# Altium schematic sheet Arduino_Mega.SchDoc
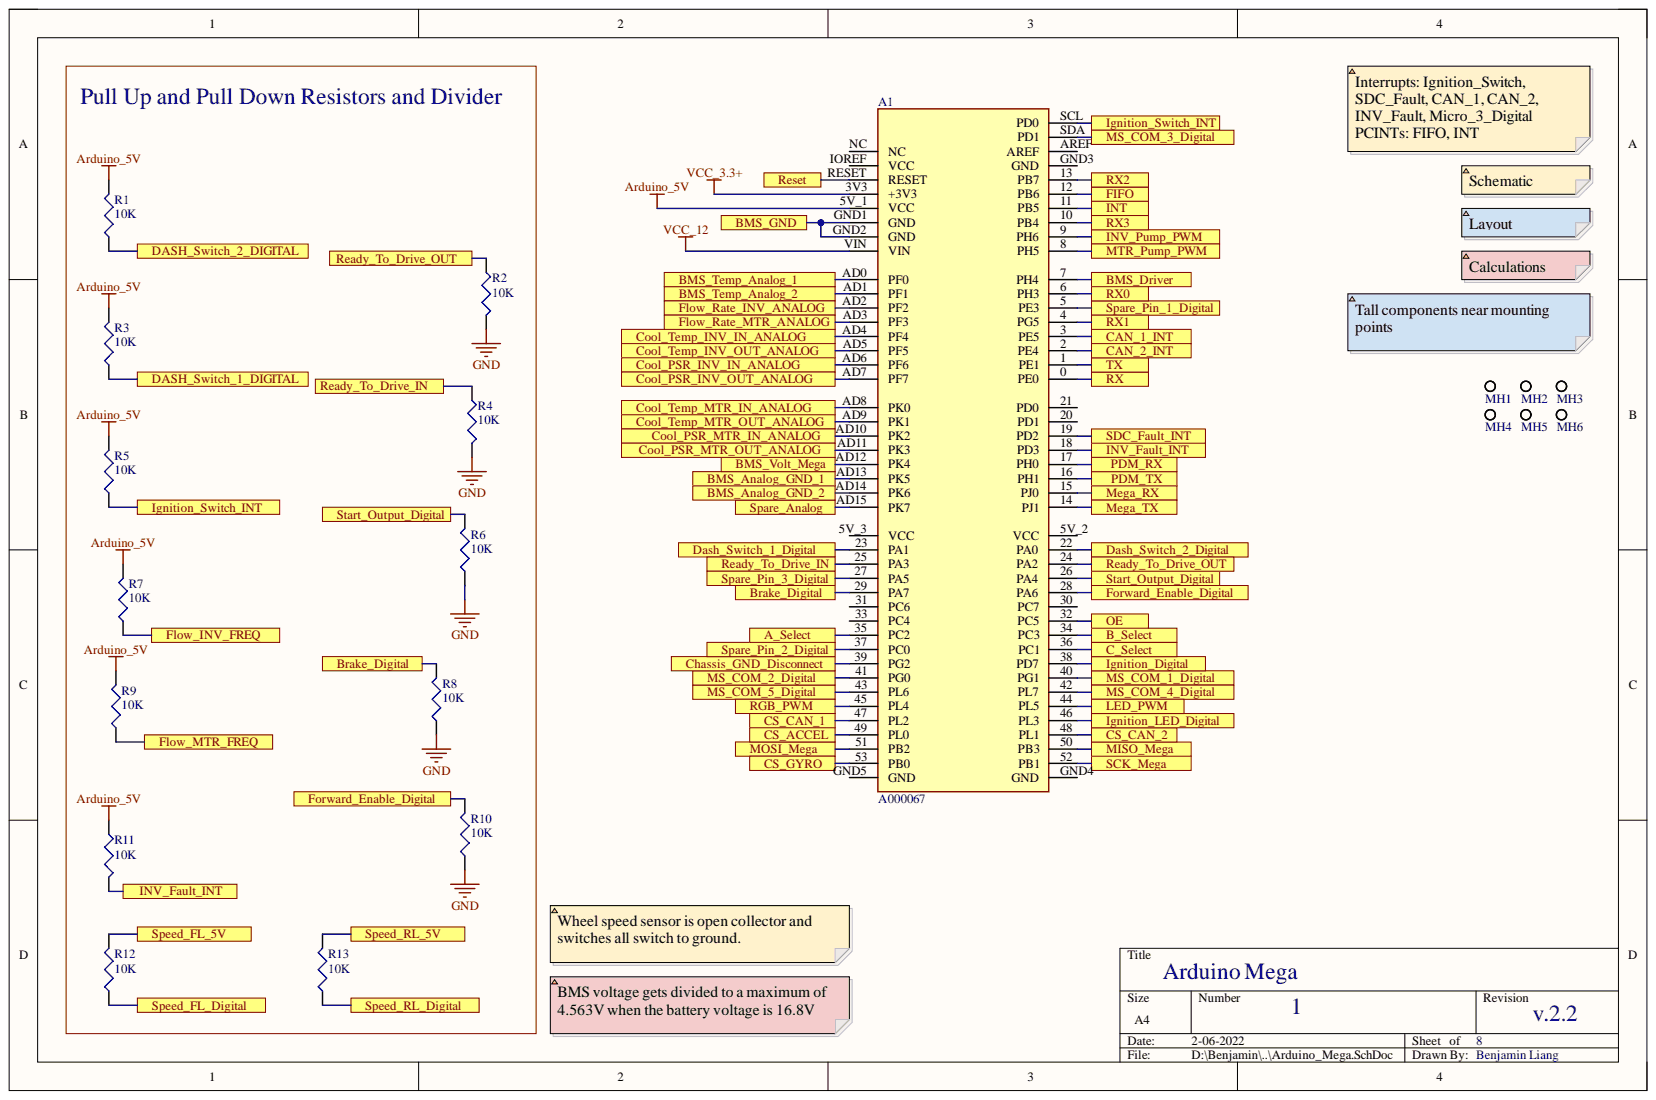
[diagram p0: Arduino_Mega.SchDoc]

## Parts
A1  A000067  [ARDUINO_A000067]
MH1  Size 10 Bolt  [PCBComponent_1]  (value not drawn)
MH2  Size 10 Bolt  [PCBComponent_1]  (value not drawn)
MH3  Size 10 Bolt  [PCBComponent_1]  (value not drawn)
MH4  Size 10 Bolt  [PCBComponent_1]  (value not drawn)
MH5  Size 10 Bolt  [PCBComponent_1]  (value not drawn)
MH6  Size 10 Bolt  [PCBComponent_1]  (value not drawn)
R1  10K  [J1-0603]
R2  10K  [J1-0603]
R3  10K  [J1-0603]
R4  10K  [J1-0603]
R5  10K  [J1-0603]
R6  10K  [J1-0603]
R7  10K  [J1-0603]
R8  10K  [J1-0603]
R9  10K  [J1-0603]
R10  10K  [J1-0603]
R11  10K  [J1-0603]
R12  10K  [J1-0603]
R13  10K  [J1-0603]

## Ports
A_Select, B_Select, BMS_Analog_GND_1, BMS_Analog_GND_2, BMS_Driver, BMS_GND, BMS_Temp_Analog_1, BMS_Temp_Analog_2, BMS_Volt_Mega, Brake_Digital, C_Select, CAN_1_INT, CAN_2_INT, Chassis_GND_Disconnect, Cool_PSR_INV_IN_ANALOG, Cool_PSR_INV_OUT_ANALOG, Cool_PSR_MTR_IN_ANALOG, Cool_PSR_MTR_OUT_ANALOG, Cool_Temp_INV_IN_ANALOG, Cool_Temp_INV_OUT_ANALOG, Cool_Temp_MTR_IN_ANALOG, Cool_Temp_MTR_OUT_ANALOG, CS_ACCEL, CS_CAN_1, CS_CAN_2, CS_GYRO, Dash_Switch_1_Digital, DASH_Switch_1_DIGITAL, Dash_Switch_2_Digital, DASH_Switch_2_DIGITAL, FIFO, Flow_INV_FREQ, Flow_MTR_FREQ, Flow_Rate_INV_ANALOG, Flow_Rate_MTR_ANALOG, Forward_Enable_Digital, Ignition_Digital, Ignition_LED_Digital, Ignition_Switch_INT, INT, INV_Fault_INT, INV_Pump_PWM, LED_PWM, Mega_RX, Mega_TX, MISO_Mega, MOSI_Mega, MS_COM_1_Digital, MS_COM_2_Digital, MS_COM_3_Digital, MS_COM_4_Digital, MS_COM_5_Digital, MTR_Pump_PWM, OE, PDM_RX, PDM_TX, Ready_To_Drive_IN, Ready_To_Drive_OUT, Reset, RGB_PWM, RX, RX0, RX1, RX2, RX3, SCK_Mega, SDC_Fault_INT, Spare_Analog, Spare_Pin_1_Digital, Spare_Pin_2_Digital, Spare_Pin_3_Digital, Speed_FL_5V, Speed_FL_Digital, Speed_RL_5V, Speed_RL_Digital, Start_Output_Digital, TX

## Nets
Arduino_5V: A1.5V_1(VCC) R1.2 R3.2 R5.2 R7.2 R9.2 R11.1
GND: R2.1 R4.1 R6.1 R8.1 R10.1
VCC_3.3+: A1.3V3(+3V3)
VCC_12: A1.VIN
A_Select: A1.35(PC2) ; port A_Select
B_Select: A1.34(PC3) ; port B_Select
BMS_Analog_GND_1: A1.AD13(PK5) ; port BMS_Analog_GND_1
BMS_Analog_GND_2: A1.AD14(PK6) ; port BMS_Analog_GND_2
BMS_Driver: A1.7(PH4) ; port BMS_Driver
BMS_GND: A1.GND1(GND) A1.GND2(GND) ; port BMS_GND
BMS_Temp_Analog_1: A1.AD0(PF0) ; port BMS_Temp_Analog_1
BMS_Temp_Analog_2: A1.AD1(PF1) ; port BMS_Temp_Analog_2
BMS_Volt_Mega: A1.AD12(PK4) ; port BMS_Volt_Mega
Brake_Digital: A1.29(PA7) R8.2 ; port Brake_Digital
C_Select: A1.36(PC1) ; port C_Select
CAN_1_INT: A1.3(PE5) ; port CAN_1_INT
CAN_2_INT: A1.2(PE4) ; port CAN_2_INT
Chassis_GND_Disconnect: A1.39(PG2) ; port Chassis_GND_Disconnect
Cool_PSR_INV_IN_ANALOG: A1.AD6(PF6) ; port Cool_PSR_INV_IN_ANALOG
Cool_PSR_INV_OUT_ANALOG: A1.AD7(PF7) ; port Cool_PSR_INV_OUT_ANALOG
Cool_PSR_MTR_IN_ANALOG: A1.AD10(PK2) ; port Cool_PSR_MTR_IN_ANALOG
Cool_PSR_MTR_OUT_ANALOG: A1.AD11(PK3) ; port Cool_PSR_MTR_OUT_ANALOG
Cool_Temp_INV_IN_ANALOG: A1.AD4(PF4) ; port Cool_Temp_INV_IN_ANALOG
Cool_Temp_INV_OUT_ANALOG: A1.AD5(PF5) ; port Cool_Temp_INV_OUT_ANALOG
Cool_Temp_MTR_IN_ANALOG: A1.AD8(PK0) ; port Cool_Temp_MTR_IN_ANALOG
Cool_Temp_MTR_OUT_ANALOG: A1.AD9(PK1) ; port Cool_Temp_MTR_OUT_ANALOG
CS_ACCEL: A1.49(PL0) ; port CS_ACCEL
CS_CAN_1: A1.47(PL2) ; port CS_CAN_1
CS_CAN_2: A1.48(PL1) ; port CS_CAN_2
CS_GYRO: A1.53(PB0) ; port CS_GYRO
Dash_Switch_1_Digital: A1.23(PA1) R3.1 ; port DASH_Switch_1_DIGITAL, port Dash_Switch_1_Digital
Dash_Switch_2_Digital: A1.22(PA0) R1.1 ; port DASH_Switch_2_DIGITAL, port Dash_Switch_2_Digital
FIFO: A1.12(PB6) ; port FIFO
Flow_INV_FREQ: R7.1 ; port Flow_INV_FREQ
Flow_MTR_FREQ: R9.1 ; port Flow_MTR_FREQ
Flow_Rate_INV_ANALOG: A1.AD2(PF2) ; port Flow_Rate_INV_ANALOG
Flow_Rate_MTR_ANALOG: A1.AD3(PF3) ; port Flow_Rate_MTR_ANALOG
Forward_Enable_Digital: A1.28(PA6) R10.2 ; port Forward_Enable_Digital
Ignition_Digital: A1.38(PD7) ; port Ignition_Digital
Ignition_LED_Digital: A1.46(PL3) ; port Ignition_LED_Digital
Ignition_Switch_INT: A1.SCL(PD0) R5.1 ; port Ignition_Switch_INT
INT: A1.11(PB5) ; port INT
INV_Fault_INT: A1.18(PD3) R11.2 ; port INV_Fault_INT
INV_Pump_PWM: A1.9(PH6) ; port INV_Pump_PWM
LED_PWM: A1.44(PL5) ; port LED_PWM
Mega_RX: A1.15(PJ0) ; port Mega_RX
Mega_TX: A1.14(PJ1) ; port Mega_TX
MISO_Mega: A1.50(PB3) ; port MISO_Mega
MOSI_Mega: A1.51(PB2) ; port MOSI_Mega
MS_COM_1_Digital: A1.40(PG1) ; port MS_COM_1_Digital
MS_COM_2_Digital: A1.41(PG0) ; port MS_COM_2_Digital
MS_COM_3_Digital: A1.SDA(PD1) ; port MS_COM_3_Digital
MS_COM_4_Digital: A1.42(PL7) ; port MS_COM_4_Digital
MS_COM_5_Digital: A1.43(PL6) ; port MS_COM_5_Digital
MTR_Pump_PWM: A1.8(PH5) ; port MTR_Pump_PWM
OE: A1.32(PC5) ; port OE
PDM_RX: A1.17(PH0) ; port PDM_RX
PDM_TX: A1.16(PH1) ; port PDM_TX
Ready_To_Drive_IN: A1.25(PA3) R4.2 ; port Ready_To_Drive_IN
Ready_To_Drive_OUT: A1.24(PA2) R2.2 ; port Ready_To_Drive_OUT
Reset: A1.RESET ; port Reset
RGB_PWM: A1.45(PL4) ; port RGB_PWM
RX: A1.0(PE0) ; port RX
RX0: A1.6(PH3) ; port RX0
RX1: A1.4(PG5) ; port RX1
RX2: A1.13(PB7) ; port RX2
RX3: A1.10(PB4) ; port RX3
SCK_Mega: A1.52(PB1) ; port SCK_Mega
SDC_Fault_INT: A1.19(PD2) ; port SDC_Fault_INT
Spare_Analog: A1.AD15(PK7) ; port Spare_Analog
Spare_Pin_1_Digital: A1.5(PE3) ; port Spare_Pin_1_Digital
Spare_Pin_2_Digital: A1.37(PC0) ; port Spare_Pin_2_Digital
Spare_Pin_3_Digital: A1.27(PA5) ; port Spare_Pin_3_Digital
Speed_FL_5V: R12.2 ; port Speed_FL_5V
Speed_FL_Digital: R12.1 ; port Speed_FL_Digital
Speed_RL_5V: R13.2 ; port Speed_RL_5V
Speed_RL_Digital: R13.1 ; port Speed_RL_Digital
Start_Output_Digital: A1.26(PA4) R6.2 ; port Start_Output_Digital
TX: A1.1(PE1) ; port TX

Not connected: A1 5V_2(VCC) 5V_3(VCC) 20(PD1) 21(PD0) 30(PC7) 31(PC6) 33(PC4) AREF GND3(GND) GND4(GND) GND5(GND) IOREF(VCC) NC

## Library data of the parts
A1 = A000067: ATmega2560 Arduino Mega2560 AVRÂ® ATmega AVR MCU 8-Bit Embedded Evaluation Board; Availability: Unavailable; Component Kind: Standard; Library Name: SnapEDAsch.SchLib; MF: Arduino; MP: A000067; Package: None; Price: None; SnapEDA_Link: https://www.snapeda.com/parts/A000067/Arduino/view-part/557398/?ref=snap
MH1-MH6 = Mounting Hole: Component Kind: Standard; Library Name: MountingHole.SchLib
R1-R13 = Res3: Resistor; Value: 220; Component Kind: Standard; LatestRevisionDate: 29-May-2009; LatestRevisionNote: IPC-7351 Footprint Added.; Library Name: Miscellaneous Devices.IntLib; PackageDescription: Chip Resistor; PackageReference: J1-0603; Published: 8-Jun-2000; Signal Integrity: Res; Simulation: RESISTOR; Publisher: Altium Limited
